# Altium schematic sheet 7-Expansion Connectors.schdoc("7-Expansion Connectors")
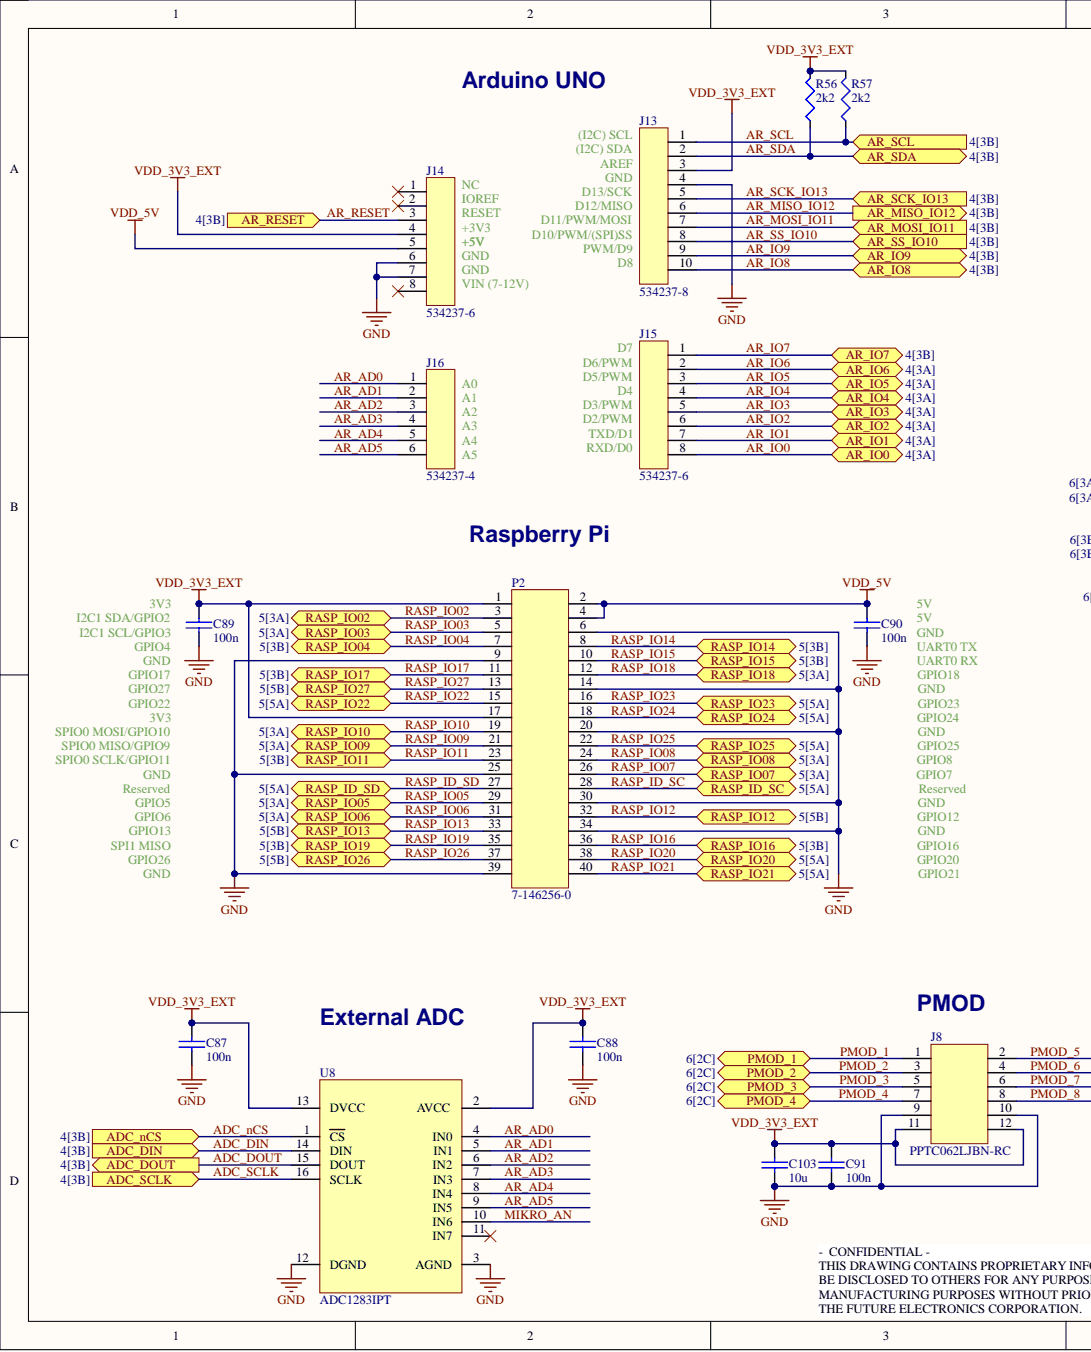
[diagram p0: 7-Expansion Connectors.schdoc, left]
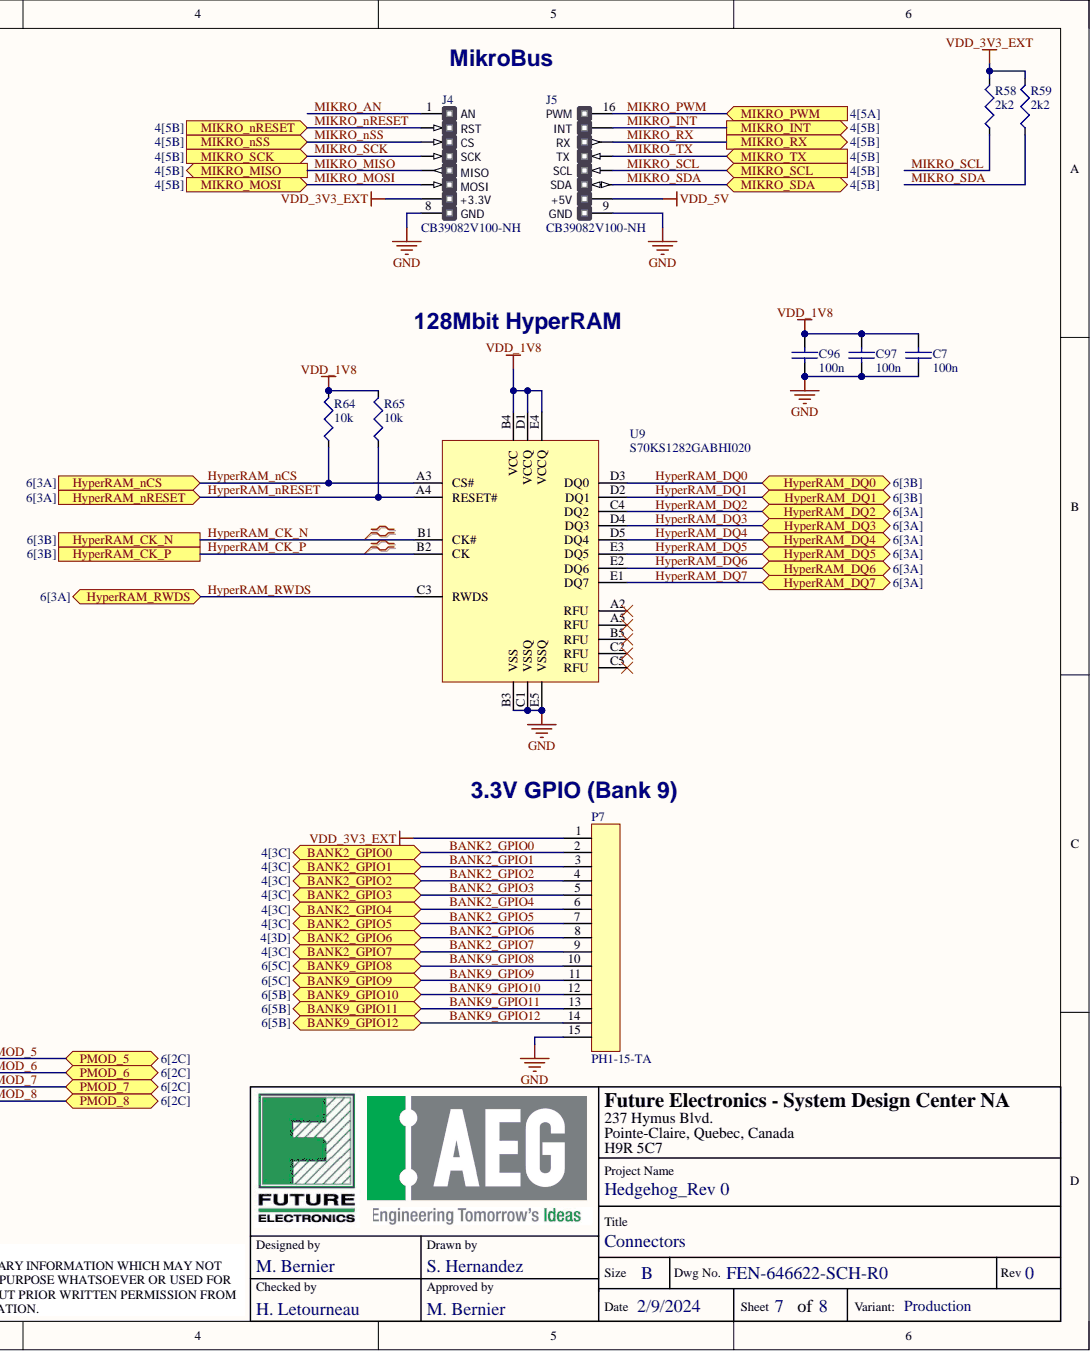
[diagram p1: 7-Expansion Connectors.schdoc, right]

## Parts
C7  value 100n  [0402]  (hidden comment: C-100nF-50V-Cer-0402)
C87  value 100n  [0402]  (hidden comment: C-100nF-50V-Cer-0402)
C88  value 100n  [0402]  (hidden comment: C-100nF-50V-Cer-0402)
C89  value 100n  [0402]  (hidden comment: C-100nF-50V-Cer-0402)
C90  value 100n  [0402]  (hidden comment: C-100nF-50V-Cer-0402)
C91  value 100n  [0402]  (hidden comment: C-100nF-50V-Cer-0402)
C96  value 100n  [0402]  (hidden comment: C-100nF-50V-Cer-0402)
C97  value 100n  [0402]  (hidden comment: C-100nF-50V-Cer-0402)
C103  value 10u  [1206]  (hidden comment: C-10uF-50V-Cer-1206_A)
J4  MFR PN: CB39082V100-NH  [mikroBus]  (hidden comment: J-mikroBUS_A-1of2)
J5  MFR PN: CB39082V100-NH  [mikroBus]  (hidden comment: J-mikroBUS_B-2of2)
J8  Future PN (TR): PPTC062LJBN-RC  [HDR100-2x6-RA-Socket-TH]  (hidden comment: J-PPTC062LJBN-RC)
J13  MFR PN: 534237-8  [HDR100-10PIN-TH-Socket_TE]  (hidden comment: J-534237-8)
J14  MFR PN: 534237-6  [HDR100-8PIN-TH-Socket_TE]  (hidden comment: J-534237-6)
J15  MFR PN: 534237-6  [HDR100-8PIN-TH-Socket_TE]  (hidden comment: J-534237-6)
J16  MFR PN: 534237-4  [HDR100-6PIN-TH-Socket_TE]  (hidden comment: J-534237-4)
P2  Future PN (TR): 7-146256-0  [HDR100_2x20_TH_Vert_TE]  (hidden comment: P-7-146256-0)
P7  MFR PN: PH1-15-TA  [HDR100-15PIN-TH]  (hidden comment: P-PH1-15-TA)
R56  value 2k2  [0402]  (hidden comment: R-2.2k-63mW-0402)
R57  value 2k2  [0402]  (hidden comment: R-2.2k-63mW-0402)
R58  value 2k2  [0402]  (hidden comment: R-2.2k-63mW-0402)
R59  value 2k2  [0402]  (hidden comment: R-2.2k-63mW-0402)
R64  value 10k  [0402]  (hidden comment: R-10k-63mW-0402)
R65  value 10k  [0402]  (hidden comment: R-10k-63mW-0402)
U8  MFR PN: ADC1283IPT  [TSSOP16_MICROCHIP]  (hidden comment: U-ADC1283IPT)
U9  Future PN (CT): S70KS1282GABHI020  [INF_BGA24]  (hidden comment: U-S70KS1282GABHI020)

## Ports
ADC_DIN, ADC_DOUT, ADC_nCS, ADC_SCLK, AR_IO0, AR_IO1, AR_IO2, AR_IO3, AR_IO4, AR_IO5, AR_IO6, AR_IO7, AR_IO8, AR_IO9, AR_MISO_IO12, AR_MOSI_IO11, AR_RESET, AR_SCK_IO13, AR_SCL, AR_SDA, AR_SS_IO10, BANK2_GPIO0, BANK2_GPIO1, BANK2_GPIO2, BANK2_GPIO3, BANK2_GPIO4, BANK2_GPIO5, BANK2_GPIO6, BANK2_GPIO7, BANK9_GPIO8, BANK9_GPIO9, BANK9_GPIO10, BANK9_GPIO11, BANK9_GPIO12, HyperRAM_CK_N, HyperRAM_CK_P, HyperRAM_DQ0, HyperRAM_DQ1, HyperRAM_DQ2, HyperRAM_DQ3, HyperRAM_DQ4, HyperRAM_DQ5, HyperRAM_DQ6, HyperRAM_DQ7, HyperRAM_nCS, HyperRAM_nRESET, HyperRAM_RWDS, MIKRO_INT, MIKRO_MISO, MIKRO_MOSI, MIKRO_nRESET, MIKRO_nSS, MIKRO_PWM, MIKRO_RX, MIKRO_SCK, MIKRO_SCL, MIKRO_SDA, MIKRO_TX, PMOD_1, PMOD_2, PMOD_3, PMOD_4, PMOD_5, PMOD_6, PMOD_7, PMOD_8, RASP_ID_SC, RASP_ID_SD, RASP_IO02, RASP_IO03, RASP_IO04, RASP_IO05, RASP_IO06, RASP_IO07, RASP_IO08, RASP_IO09, RASP_IO10, RASP_IO11, RASP_IO12, RASP_IO13, RASP_IO14, RASP_IO15, RASP_IO16, RASP_IO17, RASP_IO18, RASP_IO19, RASP_IO20, RASP_IO21, RASP_IO22, RASP_IO23, RASP_IO24, RASP_IO25, RASP_IO26, RASP_IO27

## Nets
GND: C7.2 C87.2 C88.2 C89.2 C90.2 C91.2 C96.2 C97.2 C103.2 J4.8(GND) J5.9(GND) J8.9 J8.10 J13.4 J14.6 J14.7 P2.6 P2.9 P2.14 P2.20 P2.25 P2.30 P2.34 P2.39 P7.15 U8.3(AGND) U8.12(DGND) U9.B3(VSS) U9.C1(VSSQ) U9.E5(VSSQ)
VDD_1V8: C7.1 C96.1 C97.1 R64.2 R65.2 U9.B4(VCC) U9.D1(VCCQ) U9.E4(VCCQ)
VDD_3V3_EXT: C87.1 C88.1 C89.1 C91.1 C103.1 J4.7(+3.3V) J8.11 J8.12 J13.3 J14.4 P2.1 P2.17 P7.1 R56.1 R57.1 R58.1 R59.1 U8.2(AVCC) U8.13(DVCC)
VDD_5V: C90.1 J5.10(+5V) J14.5 P2.2 P2.4
ADC_DIN: U8.14(DIN) ; port ADC_DIN
ADC_DOUT: U8.15(DOUT) ; port ADC_DOUT
ADC_nCS: U8.1(CS) ; port ADC_nCS
ADC_SCLK: U8.16(SCLK) ; port ADC_SCLK
AR_AD0: J16.1 U8.4(IN0)
AR_AD1: J16.2 U8.5(IN1)
AR_AD2: J16.3 U8.6(IN2)
AR_AD3: J16.4 U8.7(IN3)
AR_AD4: J16.5 U8.8(IN4)
AR_AD5: J16.6 U8.9(IN5)
AR_IO0: J15.8 ; port AR_IO0
AR_IO1: J15.7 ; port AR_IO1
AR_IO2: J15.6 ; port AR_IO2
AR_IO3: J15.5 ; port AR_IO3
AR_IO4: J15.4 ; port AR_IO4
AR_IO5: J15.3 ; port AR_IO5
AR_IO6: J15.2 ; port AR_IO6
AR_IO7: J15.1 ; port AR_IO7
AR_IO8: J13.10 ; port AR_IO8
AR_IO9: J13.9 ; port AR_IO9
AR_MISO_IO12: J13.6 ; port AR_MISO_IO12
AR_MOSI_IO11: J13.7 ; port AR_MOSI_IO11
AR_RESET: J14.3 ; port AR_RESET
AR_SCK_IO13: J13.5 ; port AR_SCK_IO13
AR_SCL: J13.1 R57.2 ; port AR_SCL
AR_SDA: J13.2 R56.2 ; port AR_SDA
AR_SS_IO10: J13.8 ; port AR_SS_IO10
BANK2_GPIO0: P7.2 ; port BANK2_GPIO0
BANK2_GPIO1: P7.3 ; port BANK2_GPIO1
BANK2_GPIO2: P7.4 ; port BANK2_GPIO2
BANK2_GPIO3: P7.5 ; port BANK2_GPIO3
BANK2_GPIO4: P7.6 ; port BANK2_GPIO4
BANK2_GPIO5: P7.7 ; port BANK2_GPIO5
BANK2_GPIO6: P7.8 ; port BANK2_GPIO6
BANK2_GPIO7: P7.9 ; port BANK2_GPIO7
BANK9_GPIO8: P7.10 ; port BANK9_GPIO8
BANK9_GPIO9: P7.11 ; port BANK9_GPIO9
BANK9_GPIO10: P7.12 ; port BANK9_GPIO10
BANK9_GPIO11: P7.13 ; port BANK9_GPIO11
BANK9_GPIO12: P7.14 ; port BANK9_GPIO12
HyperRAM_CK_N: U9.B1(CK#) ; port HyperRAM_CK_N
HyperRAM_CK_P: U9.B2(CK) ; port HyperRAM_CK_P
HyperRAM_DQ0: U9.D3(DQ0) ; port HyperRAM_DQ0
HyperRAM_DQ1: U9.D2(DQ1) ; port HyperRAM_DQ1
HyperRAM_DQ2: U9.C4(DQ2) ; port HyperRAM_DQ2
HyperRAM_DQ3: U9.D4(DQ3) ; port HyperRAM_DQ3
HyperRAM_DQ4: U9.D5(DQ4) ; port HyperRAM_DQ4
HyperRAM_DQ5: U9.E3(DQ5) ; port HyperRAM_DQ5
HyperRAM_DQ6: U9.E2(DQ6) ; port HyperRAM_DQ6
HyperRAM_DQ7: U9.E1(DQ7) ; port HyperRAM_DQ7
HyperRAM_nCS: R64.1 U9.A3(CS#) ; port HyperRAM_nCS
HyperRAM_nRESET: R65.1 U9.A4(RESET#) ; port HyperRAM_nRESET
HyperRAM_RWDS: U9.C3(RWDS) ; port HyperRAM_RWDS
MIKRO_AN: J4.1(AN) U8.10(IN6)
MIKRO_INT: J5.15(INT) ; port MIKRO_INT
MIKRO_MISO: J4.5(MISO) ; port MIKRO_MISO
MIKRO_MOSI: J4.6(MOSI) ; port MIKRO_MOSI
MIKRO_nRESET: J4.2(RST) ; port MIKRO_nRESET
MIKRO_nSS: J4.3(CS) ; port MIKRO_nSS
MIKRO_PWM: J5.16(PWM) ; port MIKRO_PWM
MIKRO_RX: J5.14(RX) ; port MIKRO_RX
MIKRO_SCK: J4.4(SCK) ; port MIKRO_SCK
MIKRO_SCL: J5.12(SCL) R58.2 ; port MIKRO_SCL
MIKRO_SDA: J5.11(SDA) R59.2 ; port MIKRO_SDA
MIKRO_TX: J5.13(TX) ; port MIKRO_TX
PMOD_1: J8.1 ; port PMOD_1
PMOD_2: J8.3 ; port PMOD_2
PMOD_3: J8.5 ; port PMOD_3
PMOD_4: J8.7 ; port PMOD_4
PMOD_5: J8.2 ; port PMOD_5
PMOD_6: J8.4 ; port PMOD_6
PMOD_7: J8.6 ; port PMOD_7
PMOD_8: J8.8 ; port PMOD_8
RASP_ID_SC: P2.28 ; port RASP_ID_SC
RASP_ID_SD: P2.27 ; port RASP_ID_SD
RASP_IO02: P2.3 ; port RASP_IO02
RASP_IO03: P2.5 ; port RASP_IO03
RASP_IO04: P2.7 ; port RASP_IO04
RASP_IO05: P2.29 ; port RASP_IO05
RASP_IO06: P2.31 ; port RASP_IO06
RASP_IO07: P2.26 ; port RASP_IO07
RASP_IO08: P2.24 ; port RASP_IO08
RASP_IO09: P2.21 ; port RASP_IO09
RASP_IO10: P2.19 ; port RASP_IO10
RASP_IO11: P2.23 ; port RASP_IO11
RASP_IO12: P2.32 ; port RASP_IO12
RASP_IO13: P2.33 ; port RASP_IO13
RASP_IO14: P2.8 ; port RASP_IO14
RASP_IO15: P2.10 ; port RASP_IO15
RASP_IO16: P2.36 ; port RASP_IO16
RASP_IO17: P2.11 ; port RASP_IO17
RASP_IO18: P2.12 ; port RASP_IO18
RASP_IO19: P2.35 ; port RASP_IO19
RASP_IO20: P2.38 ; port RASP_IO20
RASP_IO21: P2.40 ; port RASP_IO21
RASP_IO22: P2.15 ; port RASP_IO22
RASP_IO23: P2.16 ; port RASP_IO23
RASP_IO24: P2.18 ; port RASP_IO24
RASP_IO25: P2.22 ; port RASP_IO25
RASP_IO26: P2.37 ; port RASP_IO26
RASP_IO27: P2.13 ; port RASP_IO27

Not connected: J14 1 2 8; U8 11(IN7); U9 A2(RFU) A5(RFU) B5(RFU) C2(RFU) C5(RFU)

## Library data of the parts
C7 C87-C91 C96 C97 = C-100nF-50V-Cer-0402: Cap 0402 SM X7R 50V 10%; MFR: TDK; MFR PN: C1005X7R1H104K050BB; Future PN (CT): 04025C104KATN-CT; Future PN (TR): 04025C104KATN; Rating: 50V 10%; Component Kind: Standard; ComponentLink1Description: Datasheet; ComponentLink1URL: http://images.ihscontent.net/vipimages/VipMasterIC/IC/TDKC/TDKC-S-A0000443042/TDKC-S-A0000443042-1.pdf; Library Name: Future_Capacitors_V1.SCHLIB; Verified: AD042616
C103 = C-10uF-50V-Cer-1206_A: Cap 1206 SM X7R 50V 10%; MFR: SAMSUNG; MFR PN: CL31B106KBHNNNE; Future PN (CT): TBD; Future PN (TR): 12065C106KATN; Rating: 50V 10%; Component Kind: Standard; ComponentLink1Description: Datasheet; ComponentLink1URL: http://www.samsungsem.com/kr/support/product-search/mlcc/__icsFiles/afieldfile/2019/06/18/CL31B106KBHNNNE-19.pdf; Library Name: Future_Capacitors_V1.SCHLIB; Verified: AD112817
J4 = J-mikroBUS_A-1of2: Connector Dual 1Row Vert TH 1x8 2.54mm Socket Header; MFR: CVILUX; Future PN (CT): TBD; Rating: 1x8 Vert 2.54mm; Value: Use PN: J-mikroBUS_B-2of2 for other side of mikroBUS; VModel: MMDDYY; Component Kind: Standard; ComponentLink1Description: Datasheet; ComponentLink1URL: https://www.cvilux.com/uploads/drawings/100/625/CB3924S_HF_.pdf; Library Name: Laird_WiFi_Rev0.SCHLIB
J5 = J-mikroBUS_B-2of2: Connector Dual 1Row Vert TH 1x8 2.54mm Socket Header; MFR: CVILUX; Future PN (CT): TBD; Rating: 1x8 Vert 2.54mm; Value: Use PN: J-mikroBUS_A-1of2 for other side of mikroBUS; VModel: MMDDYY; Component Kind: Standard; ComponentLink1Description: Datasheet; ComponentLink1URL: https://www.cvilux.com/uploads/drawings/100/625/CB3924S_HF_.pdf; Future PN (TR): TBD; Library Name: Laird_WiFi_Rev0.SCHLIB
J8 = J-PPTC062LJBN-RC: Connector 2Row RA TH 2x6 2.54mm Socket Header; MFR: SULLINS; Future PN (CT): TBD; VModel: MMDDYY; Component Kind: Standard; ComponentLInk1Description: Datasheet; ComponentLink1URL: http://sullinscorp.com/drawings/101_P(N)PxCxx2LJBN-RC,_10494-H.pdf; Library Name: Future_Connectors_V1.SCHLIB; MFR PN: PPTC062LJBN-RC; Rating: 2x6 2.54mm; Verified: AD041416
J13 = J-534237-8: Connector 1Row Vert TH 1x10 2.54mm Socket Header; MFR: TE; Future PN (CT): TBD; Rating: 1x10 Vert 2.54mm; VModel: MMDDYY; Component Kind: Standard; ComponentLink1Description: Datasheet; ComponentLink1URL: https://www.te.com/commerce/DocumentDelivery/DDEController?Action=srchrtrv&DocNm=534237&DocType=Customer+Drawing&DocLang=English; Future PN (TR): TBD; Verified: TBD; Library Name: Hedgehog_Rev0.SchLib
J14 J15 = J-534237-6: Connector 1Row Vert TH 1x8 2.54mm Socket Header; MFR: TE; Future PN (CT): TBD; Rating: 1x8 Vert 2.54mm; VModel: MMDDYY; Component Kind: Standard; ComponentLink1Description: Datasheet; ComponentLink1URL: https://www.te.com/commerce/DocumentDelivery/DDEController?Action=srchrtrv&DocNm=534237&DocType=Customer+Drawing&DocLang=English; Future PN (TR): TBD; Verified: TBD; Library Name: Hedgehog_Rev0.SchLib
J16 = J-534237-4: Connector 1Row Vert TH 1x6 2.54mm Socket Header; MFR: TE; Future PN (CT): TBD; Rating: 1x6 Vert 2.54mm; VModel: MMDDYY; Component Kind: Standard; ComponentLink1Description: Datasheet; ComponentLink1URL: https://www.te.com/commerce/DocumentDelivery/DDEController?Action=srchrtrv&DocNm=534237&DocType=Customer+Drawing&DocLang=English; Future PN (TR): TBD; Verified: TBD; Library Name: Hedgehog_Rev0.SchLib
P2 = P-7-146256-0: Connector 2Row Vert TH 2x20 2.54mm Pin Header Unshrouded; MFR: TE; Future PN (CT): TBD; VModel: MMDDYY; Component Kind: Standard; ComponentLink1Description: Datasheet; ComponentLink1URL: https://www.te.com/commerce/DocumentDelivery/DDEController?Action=showdoc&DocId=Customer+Drawing%7F146256%7FH%7Fpdf%7FEnglish%7FENG_CD_146256_H.pdf%7F7-146256-0; MFR PN: 7-146256-0; Rating: 2x20 2.54mm; Created by: CZ050622; Library Name: Hedgehog_Rev0.SchLib
P7 = P-PH1-15-TA: Connector 1Row Vert TH 1x15 2.54mm Pin Header 5.9mm Tail; MFR: ADAMTECH; Future PN (CT): TBD; VModel: MMDDYY; Component Kind: Standard; ComponentLink1Description: Datasheet; ComponentLink1URL: https://4donline.ihs.com/images/VipMasterIC/IC/ADMT/ADMT-D-A0000799240/ADMT-D-A0000799340-1.pdf; Future PN (TR): TBD; Library Name: Future_Connectors_V1.SCHLIB; Rating: 1x15 2.54mm; Verified: AD060718
R56-R59 = R-2.2k-63mW-0402: Res 0402 SM 63mW 1% 100ppm; MFR: YAGEO; MFR PN: RC0402FR-072K2L; Future PN (CT): CR0402-2201FTR-CT; Future PN (TR): CR0402-2201FTR; Rating: 63mW 1%; Component Kind: Standard; ComponentLink1Description: Datasheet; ComponentLink1URL: https://www.yageo.com/upload/media/product/products/datasheet/rchip/PYu-RC_Group_51_RoHS_L_10.pdf; Library Name: Future_Resistors_V1.SCHLIB
R64 R65 = R-10k-63mW-0402: Res 0402 SM 63mW 1% 100ppm; MFR: YAGEO; MFR PN: RC0402FR-0710KL; Future PN (CT): CR0402-1002FTR-CT; Future PN (TR): CR0402-1002FTR; Rating: 63mW 1%; Component Kind: Standard; ComponentLink1Description: Datasheet; ComponentLink1URL: https://www.yageo.com/upload/media/product/products/datasheet/rchip/PYu-RC_Group_51_RoHS_L_10.pdf; Library Name: Future_Resistors_V1.SCHLIB
U8 = U-ADC1283IPT: Analog Multiplexer 8-Ch TSSOP-16 SM 2.7-5.5Vop; MFR: ST; Future PN (CT): ADC1283IPT-CT; Future PN (TR): TBD; VModel: MMDDYY; Component Kind: Standard; ComponentLink1Description: Datasheet; ComponentLink1URL: https://www.st.com/resource/en/datasheet/adc1283.pdf; Rating: 2.7-5.5Vop; Verified: TBD; Library Name: Hedgehog_Rev0.SchLib
U9 = U-S70KS1282GABHI020: Memory HyperRAM Parallel BGA24 SM 1.8-3.0Vop 128MBit 35ns 200MHz; MFR: INFINEON; Rating: 200MHz 1.8V; VModel: MMDDYY; Component Kind: Standard; ComponentLink1Description: Datasheet; ComponentLink1URL: https://www.infineon.com/dgdl/Infineon-S70KL1282_S70KS1282_128_Mb_HYPERRAM_self-refresh_DRAM_PSRAM_HYPERBUS_interface_1_8_V_3-DataSheet-v05_00-EN.pdf?fileId=8ac78c8c7d0d8da4017d0ee9315b7210; Future PN (TR): S70KS1282GABHI020; MFR PN: S70KS1282GABHI020; Library Name: Hedgehog_Rev0.SchLib
